# Altium schematic sheet [08]-PowerSupply.SchDoc
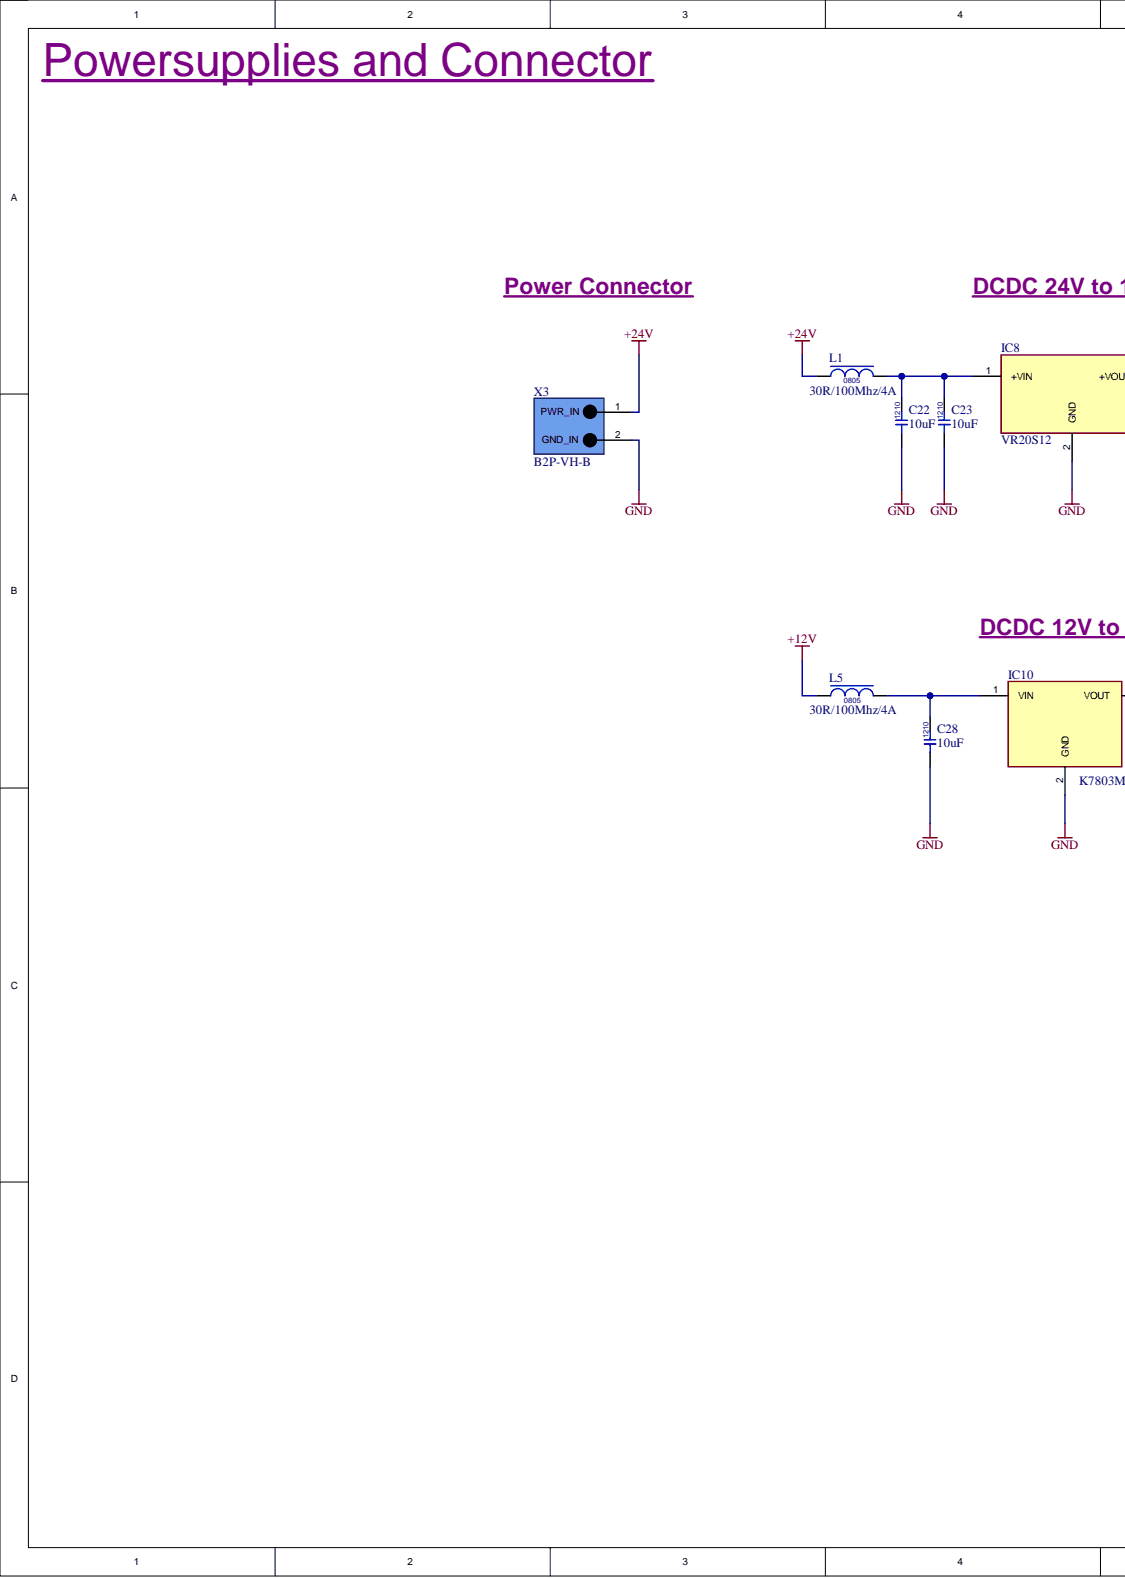
[diagram p0: [08]-PowerSupply.SchDoc, left]
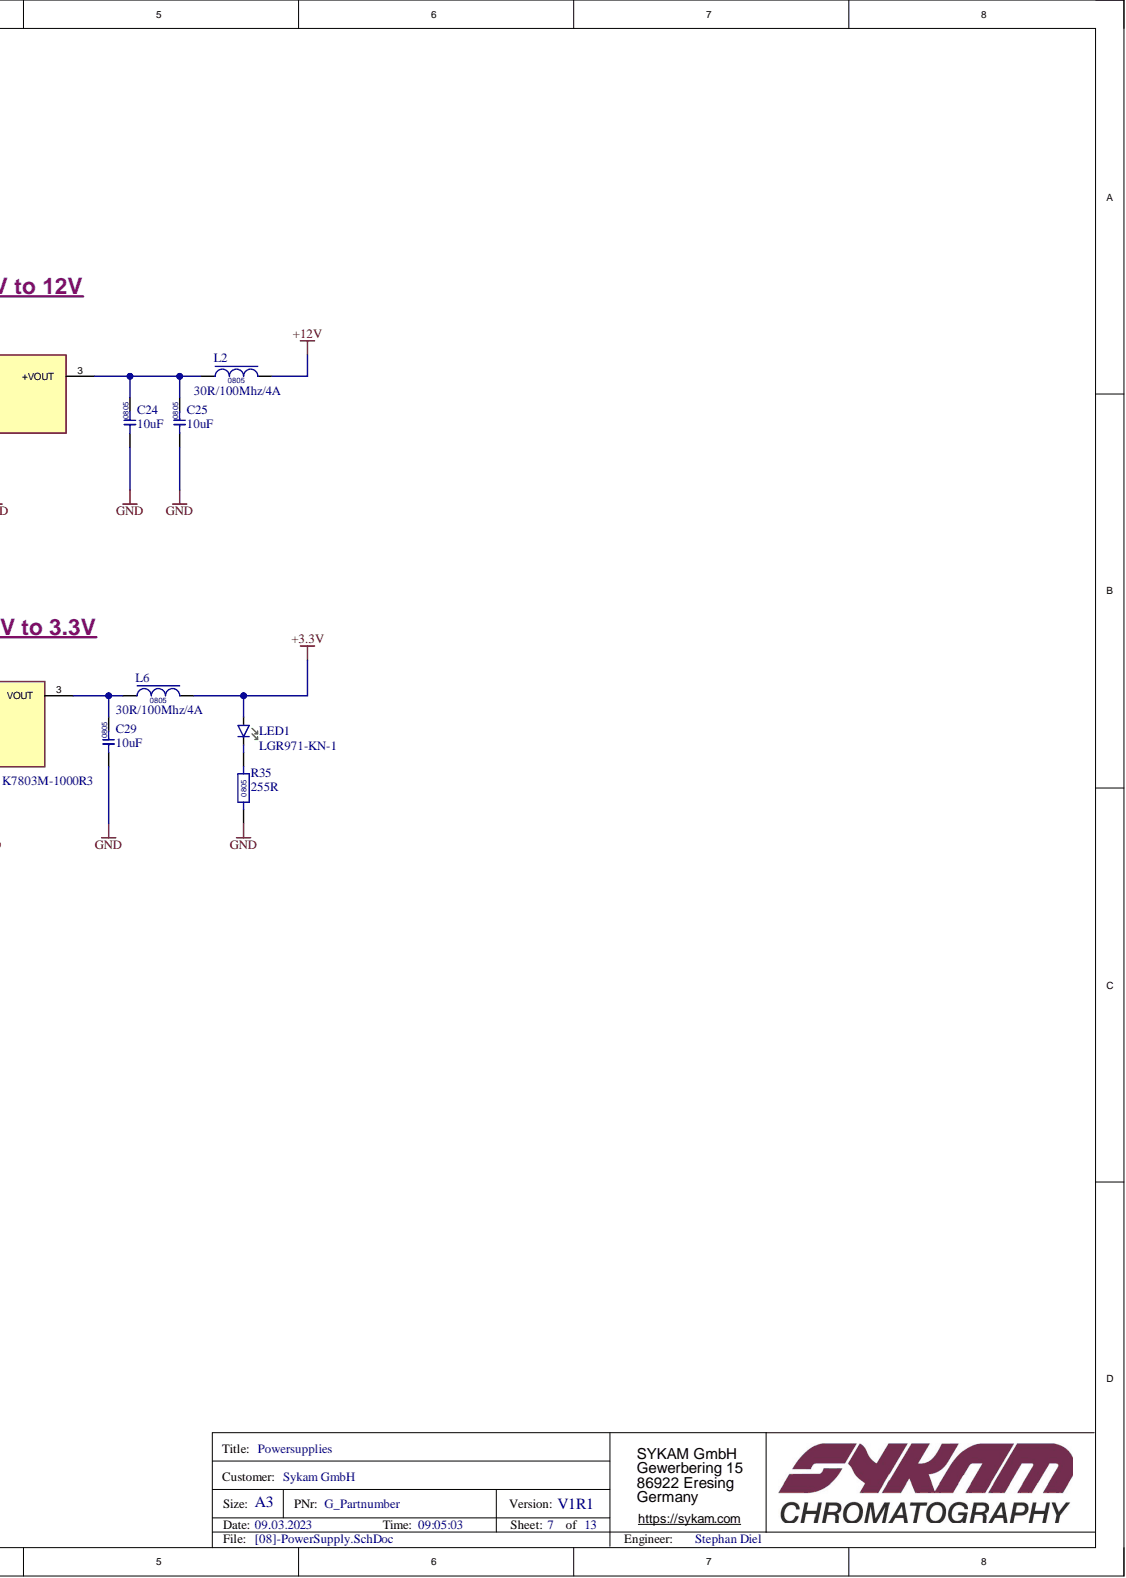
[diagram p1: [08]-PowerSupply.SchDoc, right]

## Parts
C22  10uF  Case Code (Imperial): 1210  [C1210]
C23  10uF  Case Code (Imperial): 1210  [C1210]
C24  10uF  Case Code (Imperial): 0805  [C0805]
C25  10uF  Case Code (Imperial): 0805  [C0805]
C28  10uF  Case Code (Imperial): 1210  [C1210]
C29  10uF  Case Code (Imperial): 0805  [C0805]
IC8  VR20S12  [IC_VR20S12_THT]
IC10  K7803M-1000R3  [IC_K7803M-1000R3_THT]
L1  30R/100Mhz/4A  Case Code (Imperial): 0805  [L0805]
L2  30R/100Mhz/4A  Case Code (Imperial): 0805  [L0805]
L5  30R/100Mhz/4A  Case Code (Imperial): 0805  [L0805]
L6  30R/100Mhz/4A  Case Code (Imperial): 0805  [L0805]
LED1  LGR971-KN-1  [LED0805]
R35  255R  Case Code (Imperial): 0805  [R0805]
X3  B2P-VH-B  [CON-B2P-VH-B-3.96MM_nn]

## Nets
+3.3V: L6.2 LED1.2A
+12V: L2.2 L5.2
+24V: L1.1 X3.1
GND: C22.1 C23.1 C24.1 C25.1 C28.1 C29.1 IC8.2 IC10.2 R35.1 X3.2
NetC22_2: C22.2 C23.2 IC8.1 L1.2
NetC24_2: C24.2 C25.2 IC8.3 L2.1
NetC28_2: C28.2 IC10.1 L5.1
NetC29_2: C29.2 IC10.3 L6.1
NetLED1_1K: LED1.1K R35.2

## Library data of the parts
C22 C23 C28 = C_10uF_C1210C106K5RACTU: CAP CER 10UF 50V X7R 1210; Manufacturer: KEMET; Dielectric Material: Ceramic; Tolerance: 10%; Capacitance: 10uF; Case Code (Metric): 3225; Case/Package: 1210; Category: Ceramic Capacitors; Component Kind: Standard; ComponentLink1Description: http://www.mouser.com/ds/2/212/KEM_C1002_X7R_SMD-357932.pdf?src-supplier=Mouser; ComponentLink1URL: http://www.mouser.com/ds/2/212/KEM_C1002_X7R_SMD-357932.pdf?src-supplier=Mouser; ComponentLink2Description: http://uk.rs-online.com/webdocs/1515/0900766b8151523b.pdf?src-supplier=RSComponents; ComponentLink2URL: http://uk.rs-online.com/webdocs/1515/0900766b8151523b.pdf?src-supplier=RSComponents; ComponentLink3Description: http://www.farnell.com/datasheets/2740742.pdf?src-supplier=Farnell; ComponentLink3URL: http://www.farnell.com/datasheets/2740742.pdf?src-supplier=Farnell; ComponentLink4Description: https://api.kemet.com/component-edge/download/datasheet/C1210C106K5RACTU.pdf?src-supplier=Digi-Key; ComponentLink4URL: https://api.kemet.com/component-edge/download/datasheet/C1210C106K5RACTU.pdf?src-supplier=Digi-Key; ComponentLink5Description: http://capacitoredge.kemet.com/capedge2/DataSheet/Datasheet-C0402C471J3GACTU.pdf?pn=C1210C106K5RACTU&src-supplier=Avnet; ComponentLink5URL: http://capacitoredge.kemet.com/capedge2/DataSheet/Datasheet-C0402C471J3GACTU.pdf?pn=C1210C106K5RACTU&src-supplier=Avnet; ComponentLink6Description: http://www.farnell.com/datasheets/2740742.pdf?src-supplier=Element14; ComponentLink6URL: http://www.farnell.com/datasheets/2740742.pdf?src-supplier=Element14; ComponentLink7Description: http://capacitoredge.kemet.com/capedge2/DataSheet/Datasheet-C1210C106K5RACTU.pdf?pn=C1210C106K5RACTU&src-supplier=Avnet; ComponentLink7URL: http://capacitoredge.kemet.com/capedge2/DataSheet/Datasheet-C1210C106K5RACTU.pdf?pn=C1210C106K5RACTU&src-supplier=Avnet; ComponentLink8Description: http://www.farnell.com/datasheets/1886292.pdf?src-supplier=Newark; ComponentLink8URL: http://www.farnell.com/datasheets/1886292.pdf?src-supplier=Newark; ComponentLink9Description: http://www.farnell.com/datasheets/2740742.pdf?src-supplier=Newark; ComponentLink9URL: http://www.farnell.com/datasheets/2740742.pdf?src-supplier=Newark; Depth: 2.5mm; Dielectric: X7R; Height: 2.5mm; Length: 3.2mm; Manufacturer Part Number: C1210C106K5RACTU; Max Operating Temperature: 125°C; Min Operating Temperature: -55°C; Mount: Surface Mount; Package Quantity: 1000; Packaging: Tape and Reel; Pricing 1: 1=0,90000, 10=0,66400, 100=0,45540, 500=0,36052 (USD); REACH SVHC: No SVHC; RoHS: Yes; Stock 1: 21988; Supplier 1: Digi-Key; Supplier Part Number 1: 399-11629-1-ND; Termination: SMD/SMT; Thickness: 0.11inch; Voltage: 50V; Voltage Rating: 50V; Voltage Rating (DC): 50V; Weight: 0.000564oz; Width: 2.5mm; Library Name: ToradexMainBoard-V0I1.SCHLIB
C24 C25 C29 = C_10uF_GRM21BR6YA106ME43L: 0805 10 uF 50 V ±20% Tolerance X5R Multilayer Ceramic Capacitor; Manufacturer: Murata; Voltage Rating: 35V; Capacitance: 10uF; Case Code (Metric): 2012; Case/Package: 0805; Category: Ceramic Capacitors; Component Kind: Standard; ComponentLink1Description: http://www.mouser.com/ds/2/281/c02e-2905.pdf?src-supplier=Mouser; ComponentLink1URL: http://www.mouser.com/ds/2/281/c02e-2905.pdf?src-supplier=Mouser; ComponentLink2Description: http://search.murata.co.jp/Ceramy/image/img/A01X/G101/ENG/GRM21BR6YA106ME43-01.pdf?src-supplier=Digi-Key; ComponentLink2URL: http://search.murata.co.jp/Ceramy/image/img/A01X/G101/ENG/GRM21BR6YA106ME43-01.pdf?src-supplier=Digi-Key; Dielectric: X5R; Height: 1.25mm; Length: 0.079inch; Manufacturer Part Number: GRM21BR6YA106ME43L; Max Operating Temperature: 85°C; Min Operating Temperature: -55°C; Mount: Surface Mount; Package Quantity: 3000; Packaging: Tape and Reel; Pricing 1: 1=0,48000, 10=0,33200, 100=0,19920, 500=0,14942, 1000=0,12617 (USD); RoHS: Yes; Stock 1: 143980; Supplier 1: Digi-Key; Supplier Part Number 1: 490-10515-6-ND; Termination: SMD/SMT; Thickness: 0.057inch; Tolerance: 20%; Voltage Rating (DC): 35V; Weight: 0.000335oz; Width: 0.049inch; Library Name: ToradexMainBoard-V0I1.SCHLIB
IC8 = IC_VR20S12: DC-DC Converter, Switching Regulater, 2A, SIP, VR Series; Manufacturer: XP Power; Category: Board Mount Modules; Component Kind: Standard; Manufacturer Part Number: VR20S12; Pricing 1: 1=6,07000, 5=5,88000, 10=5,70000, 20=5,46000, 50=5,10000 (EUR); RoHS: No; Supplier 1: Farnell; Supplier Part Number 1: 3525433; Library Name: ToradexMainBoard-V0I1.SCHLIB
IC10 = IC_K7803M-1000R3: Nichtisoliertes PoL-Modul DC/DC-Wandler 1 Ausgang 3,3V 1A 6V - 36V Eingang; Component Kind: Standard; Library Name: ToradexMainBoard-V0I1.SCHLIB
L1 L2 L5 L6 = L_BLM21PG300SN1D: Ferrite Beads Multi-Layer 30Ohm 100MHz 4A 14mOhm DCR 0805 T/R; Manufacturer: Murata; DC Current: 3A; DC Resistance (DCR): 14mR; Impedance: 30R; Material: Steel,Ferrite; Number of Circuits: 1; PCBChecked: initials after Checked; Resistance: 15mR; Shielding: Unshielded; Tolerance: 25%; Case Code (Metric): 2012; Case/Package: 0805; Category: Ferrite Beads and Chips; Component Kind: Standard; ComponentLink1Description: https://datasheet.ciiva.com/11795/0900766b80021a8e-11795584.pdf?src-supplier=RSComponents; ComponentLink1URL: https://datasheet.ciiva.com/11795/0900766b80021a8e-11795584.pdf?src-supplier=RSComponents; ComponentLink2Description: https://datasheet.ciiva.com/19460/1845339-19460237.pdf?src-supplier=Jameco; ComponentLink2URL: https://datasheet.ciiva.com/19460/1845339-19460237.pdf?src-supplier=Jameco; ComponentLink3Description: https://datasheet.ciiva.com/25903/l0110s0100blm21p-25903510.pdf?src-supplier=Avnet+Europe; ComponentLink3URL: https://datasheet.ciiva.com/25903/l0110s0100blm21p-25903510.pdf?src-supplier=Avnet+Europe; ComponentLink4Description: https://datasheet.ciiva.com/3081/l0110s0100blm21p-3081657.pdf?src-supplier=Avnet; ComponentLink4URL: https://datasheet.ciiva.com/3081/l0110s0100blm21p-3081657.pdf?src-supplier=Avnet; ComponentLink5Description: https://datasheet.ciiva.com/16199/l0110s0100blm21p-16199625.pdf?src-supplier=Future+Electronics; ComponentLink5URL: https://datasheet.ciiva.com/16199/l0110s0100blm21p-16199625.pdf?src-supplier=Future+Electronics; ComponentLink6Description: https://datasheet.ciiva.com/3081/l0110s0100blm21p-3081658.pdf?src-supplier=Avnet; ComponentLink6URL: https://datasheet.ciiva.com/3081/l0110s0100blm21p-3081658.pdf?src-supplier=Avnet; ComponentLink7Description: https://datasheet.ciiva.com/6212/l0110s0100blm21p-6212358.pdf?src-supplier=Digi-Key; ComponentLink7URL: https://datasheet.ciiva.com/6212/l0110s0100blm21p-6212358.pdf?src-supplier=Digi-Key; ComponentLink8Description: https://datasheet.ciiva.com/6212/l0110s0100blm21p-6212360.pdf?src-supplier=Digi-Key; ComponentLink8URL: https://datasheet.ciiva.com/6212/l0110s0100blm21p-6212360.pdf?src-supplier=Digi-Key; ComponentLink9Description: https://datasheet.ciiva.com/8021/1756152-8021599.pdf?src-supplier=Element14; ComponentLink9URL: https://datasheet.ciiva.com/8021/1756152-8021599.pdf?src-supplier=Element14; ComponentLink10Description: https://datasheet.ciiva.com/41449/001172365-da-01-en-drossel-0805-30r0-2-0x1-25mm-4000st-41449606.pdf?src-supplier=Conrad; ComponentLink10URL: https://datasheet.ciiva.com/41449/001172365-da-01-en-drossel-0805-30r0-2-0x1-25mm-4000st-41449606.pdf?src-supplier=Conrad; Core Material: Ferrite; Current Rating: 4A; Depth: 1.25mm; Frequency: 100MHz; Height: 0.85mm; IPC7352C: done; Lead Free: Lead Free; Length: 2mm; Manufacturer Part Number: BLM21PG300SN1D; Max Current Rating: 4A; Max DC Current: 3A; Max Operating Temperature: 125°C; Military Standard: Not; Min Operating Temperature: -55°C; Number of Lines: 1; Number of Pins: 2; Package Quantity: 1; Packaging: Tape and Reel; Radiation Hardening: No; REACH SVHC: No SVHC; RoHS: Yes; Stock 1: 88452; Supplier 1: Digi-Key; Supplier Part Number 1: 490-1052-1-ND; Termination: SMD/SMT; Test Frequency: 100MHz; Width: 1.25mm; Library Name: ToradexMainBoard-V0I1.SCHLIB; SCHChecked: Initials after checked
LED1 = LED_LGR971-KN-1: LED Uni-Color Green 572nm 2-Pin SMD T/R; Manufacturer: Osram Opto; Dominant Wavelength: 570nm; Forward Current: 25mA; Forward Voltage: 2.2V; Lens Color: White; Lens Size: 1.25mm; Lens Style: Diffused,Flat,Colorless,Rectangular; Lens Transparency: Diffused; Luminous Flux: 0.1lm; Luminous Intensity: 45mcd; Number of Elements: 1; Power Dissipation: 65mW; Reverse Voltage: 12V; Test Current: 20mA; Viewing Angle: 160°; Case Code (Imperial): 0805; Case Code (Metric): 2012; Case/Package: 0805; Category: LEDs; Color: Green; Component Kind: Standard; ComponentLink1Description: https://datasheet.ciiva.com/15198/getdatasheetpartid-233880-15198360.pdf?src-supplier=Verical; ComponentLink1URL: https://datasheet.ciiva.com/15198/getdatasheetpartid-233880-15198360.pdf?src-supplier=Verical; ComponentLink2Description: https://datasheet.ciiva.com/15198/getdatasheetpartid-462214-15198395.pdf?src-supplier=Verical; ComponentLink2URL: https://datasheet.ciiva.com/15198/getdatasheetpartid-462214-15198395.pdf?src-supplier=Verical; ComponentLink3Description: https://datasheet.ciiva.com/4688/g-r971-221296-4688589.pdf?src-supplier=Mouser; ComponentLink3URL: https://datasheet.ciiva.com/4688/g-r971-221296-4688589.pdf?src-supplier=Mouser; ComponentLink4Description: https://datasheet.ciiva.com/14918/00078860-0-14918604.pdf?src-supplier=Digi-Key; ComponentLink4URL: https://datasheet.ciiva.com/14918/00078860-0-14918604.pdf?src-supplier=Digi-Key; ComponentLink5Description: https://datasheet.ciiva.com/14918/00078860-0-14918605.pdf?src-supplier=Digi-Key; ComponentLink5URL: https://datasheet.ciiva.com/14918/00078860-0-14918605.pdf?src-supplier=Digi-Key; ComponentLink6Description: https://datasheet.ciiva.com/14918/00078860-0-14918606.pdf?src-supplier=Digi-Key; ComponentLink6URL: https://datasheet.ciiva.com/14918/00078860-0-14918606.pdf?src-supplier=Digi-Key; Depth: 1.35mm; Height: 0.9mm; Illumination Color: Green; Length: 2.1mm; Manufacturer Part Number: LGR971-KN-1; Max Operating Temperature: 85°C; Min Operating Temperature: -30°C; Mount: Surface Mount; Number of LEDs: 1; Number of Pins: 2; Package Quantity: 1; Packaging: Tape and Reel; Pricing 1: 1=0,26000, 10=0,19100, 100=0,09050, 500=0,06036, 1000=0,04728 (USD); Radiation Hardening: No; RoHS: Yes; Stock 1: 89127; Supplier 1: Digi-Key; Supplier Part Number 1: 475-1410-1-ND; Width: 1.25mm; Library Name: ToradexMainBoard-V0I1.SCHLIB
R35 = R_255R_RC0805FR-07255RL: Res Thick Film 0805 255 Ohm 1% 0.125W(1/8W) ±100ppm/C Epoxy SMD T/R; Manufacturer: Yageo; Max Power Dissipation: 125mW; Temperature Coefficient: 100ppm/°C; Voltage Rating: 150V; Case Code (Metric): 2012; Case/Package: 0805; Category: Chip SMD Resistors; Component Kind: Standard; ComponentLink1Description: https://datasheet.ciiva.com/2457/pyu-r-int-thick-7-2457200.pdf?src-supplier=Digi-Key; ComponentLink1URL: https://datasheet.ciiva.com/2457/pyu-r-int-thick-7-2457200.pdf?src-supplier=Digi-Key; ComponentLink2Description: https://datasheet.ciiva.com/2457/pyu-r-int-thick-7-2457201.pdf?src-supplier=Digi-Key; ComponentLink2URL: https://datasheet.ciiva.com/2457/pyu-r-int-thick-7-2457201.pdf?src-supplier=Digi-Key; ComponentLink3Description: https://datasheet.ciiva.com/2457/pyu-r-int-thick-7-2457202.pdf?src-supplier=Digi-Key; ComponentLink3URL: https://datasheet.ciiva.com/2457/pyu-r-int-thick-7-2457202.pdf?src-supplier=Digi-Key; Composition: Thick Film; Contact Plating: Tin,Matte; Depth: 1.25mm; Features: Moisture Resistant; Height: 0.024inch; Lead Free: Lead Free; Length: 2mm; Manufacturer Part Number: RC0805FR-07255RL; Max Operating Temperature: 155°C; Military Standard: Not; Min Operating Temperature: -55°C; Number of Terminations: 2; Package Quantity: 1; Packaging: Tape and Reel; Power Rating: 125mW; Pricing 1: 1=0,10000, 10=0,04000, 100=0,01630, 1000=0,00731, 2500=0,00635 (USD); Radiation Hardening: No; Resistance: 255R; RoHS: Yes; Stock 1: 5010; Supplier 1: Digi-Key; Supplier Part Number 1: 541-255CCT-ND; Termination: SMD/SMT; Tolerance: 1%; Voltage Rating (DC): 150V; Width: 0.049inch; Library Name: ToradexMainBoard-V0I1.SCHLIB
X3 = CON_2p_B2P-VH-B(LF)(SN): CONN HEADER TOP 2POS 3.96MM VH; Manufacturer: JST; Connector Type: Male Pin; Number of Positions: 2; PCBChecked: initials after Checked; Pitch: 0.156inch; Category: Connectors; Color: Natural; Component Kind: Standard; ComponentLink1Description: https://datasheet.ciiva.com/6853/evh-6853485.pdf?src-supplier=Digi-Key; ComponentLink1URL: https://datasheet.ciiva.com/6853/evh-6853485.pdf?src-supplier=Digi-Key; ComponentLink2Description: https://datasheet.ciiva.com/16358/b2p-vh-blfsn-16358717.pdf?src-supplier=Future+Electronics; ComponentLink2URL: https://datasheet.ciiva.com/16358/b2p-vh-blfsn-16358717.pdf?src-supplier=Future+Electronics; Contact Mating Length: 0.303inch; Contact Plating: Tin; Fastening Type: Friction,Ramp; IPC7352C: initials after Checked; Lead Free: Lead Free; Manufacturer Part Number: B2P-VH-B(LF)(SN); Mount: Through Hole; Number of Rows: 1; Packaging: Bulk; Pricing 1: 50=0,19300, 500=0,16400, 1250=0,15500, 2500=0,14500, 5000=0,13500 (EUR); RoHS: Yes; Supplier 1: RSComponents; Supplier Part Number 1: 7495193; Termination: Solder; Library Name: ToradexMainBoard-V0I1.SCHLIB; SCHChecked: initials after Checked
